# Altium schematic sheet dsPIC.SchDoc(dsPIC)
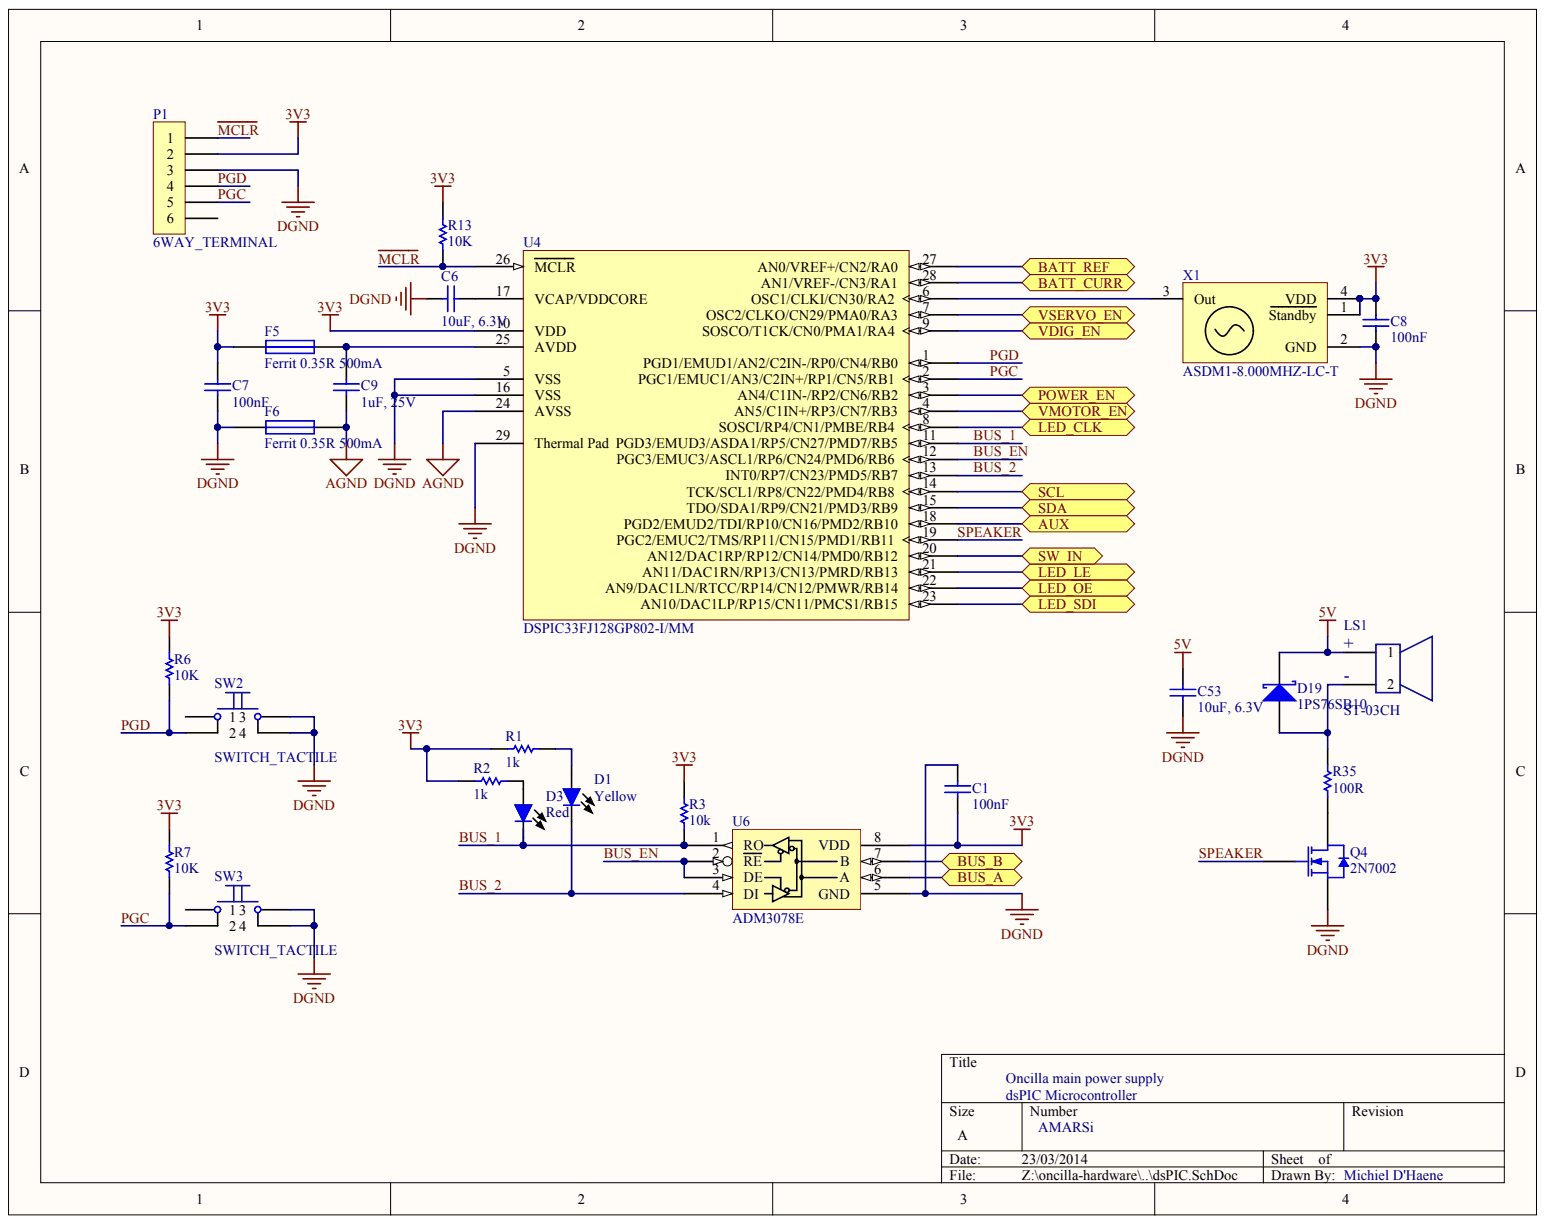
[diagram p0: dsPIC.SchDoc]

## Parts
C1  100nF
C6  10uF, 6.3V
C7  100nF
C8  100nF
C9  1uF, 25V
C53  value 10uF, 6.3V  (hidden comment: 1uF, 25V)
D1  Color: Yellow  (hidden comment: LED Yellow)
D3  Color: Red  (hidden comment: LED Red)
D19  1PS76SB10
F5  Ferrit 0.35R 500mA
F6  Ferrit 0.35R 500mA
LS1  ST-03CH
P1  6WAY_TERMINAL
Q4  2N7002
R1  1k
R2  1k
R3  10k
R6  10k
R7  10k
R13  10k
R35  100R
SW2  SWITCH_TACTILE
SW3  SWITCH_TACTILE
U4  DSPIC33FJ128GP802-I/MM
U6  ADM3078E
X1  ASDM1-8.000MHZ-LC-T

## Ports
AUX, BATT_CURR, BATT_REF, BUS_A, BUS_B, LED_CLK, LED_LE, LED_SDI, LED_~{OE}, POWER_EN, SCL, SDA, SW_IN, VDIG_EN, VMOTOR_EN, VSERVO_EN

## Nets
3V3: C1.1 C7.2 C8.2 F5.1 P1.2 R1.1 R2.1 R3.2 R6.2 R7.2 R13.2 U4.10(VDD) U6.8(VDD) X1.1(Standby) X1.4(VDD)
5V: C53.1 D19.1 LS1.1
AGND: C9.1 F6.2 U4.24(AVSS)
DGND: C1.2 C6.1 C7.1 C8.1 C53.2 F6.1 P1.3 Q4.2 SW2.3 SW2.4 SW3.3 SW3.4 U4.5(VSS) U4.16(VSS) U4.29(Thermal_Pad) U6.5(GND) X1.2(GND)
AUX: U4.18(PGD2/EMUD2/TDI/RP10/CN16/PMD2/RB10) ; port AUX
BATT_CURR: U4.28(AN1/VREF-/CN3/RA1) ; port BATT_CURR
BATT_REF: U4.27(AN0/VREF+/CN2/RA0) ; port BATT_REF
BUS_1: D3.2 R3.1 U4.11(PGD3/EMUD3/ASDA1/RP5/CN27/PMD7/RB5) U6.1(RO)
BUS_2: D1.2 U4.13(INT0/RP7/CN23/PMD5/RB7) U6.4(DI)
BUS_A: U6.6(A) ; port BUS_A
BUS_B: U6.7(B) ; port BUS_B
BUS_EN: U4.12(PGC3/EMUC3/ASCL1/RP6/CN24/PMD6/RB6) U6.2(RE) U6.3(DE)
LED_CLK: U4.8(SOSCI/RP4/CN1/PMBE/RB4) ; port LED_CLK
LED_LE: U4.21(AN11/DAC1RN/RP13/CN13/PMRD/RB13) ; port LED_LE
LED_~{OE}: U4.22(AN9/DAC1LN/RTCC/RP14/CN12/PMWR/RB14) ; port LED_~{OE}
LED_SDI: U4.23(AN10/DAC1LP/RP15/CN11/PMCS1/RB15) ; port LED_SDI
~{MCLR}: P1.1 R13.1 U4.26(MCLR)
PGC: P1.5 R7.1 SW3.2 U4.2(PGC1/EMUC1/AN3/C2IN+/RP1/CN5/RB1)
PGD: P1.4 R6.1 SW2.2 U4.1(PGD1/EMUD1/AN2/C2IN-/RP0/CN4/RB0)
POWER_EN: U4.3(AN4/C1IN-/RP2/CN6/RB2) ; port POWER_EN
SCL: U4.14(TCK/SCL1/RP8/CN22/PMD4/RB8) ; port SCL
SDA: U4.15(TDO/SDA1/RP9/CN21/PMD3/RB9) ; port SDA
SPEAKER: Q4.1 U4.19(PGC2/EMUC2/TMS/RP11/CN15/PMD1/RB11)
SW_IN: U4.20(AN12/DAC1RP/RP12/CN14/PMD0/RB12) ; port SW_IN
VDIG_EN: U4.9(SOSCO/T1CK/CN0/PMA1/RA4) ; port VDIG_EN
VMOTOR_EN: U4.4(AN5/C1IN+/RP3/CN7/RB3) ; port VMOTOR_EN
VSERVO_EN: U4.7(OSC2/CLKO/CN29/PMA0/RA3) ; port VSERVO_EN
NetC6_2: C6.2 U4.17(VCAP/VDDCORE)
NetC9_2: C9.2 F5.2 U4.25(AVDD)
NetD1_1: D1.1 R1.2
NetD3_1: D3.1 R2.2
NetD19_2: D19.2 LS1.2 R35.1
NetQ4_3: Q4.3 R35.2
NetU4_6: U4.6(OSC1/CLKI/CN30/RA2) X1.3(Out)

Not connected: P1 6; SW2 1; SW3 1

## Library data of the parts
C53 = (no symbol name): Value: 10uF, 6.3V; Published: 8-Jun-2000; LatestRevisionDate: 29-May-2009; LatestRevisionNote: IPC-7351 Footprint Added.; PackageReference: C1206; PackageDescription: Chip Capacitor; Publisher: Altium Limited
X1 = (no symbol name): Name: ASDM1; Value: 8MHz
C1 C6-C9 D1 D3 D19 F5 F6 LS1 P1 Q4 R1-R3 R6 R7 R13 R35 SW2 SW3 U4 U6 = (no symbol name)
  C1 C7 C8: Published: 8-Jun-2000; LatestRevisionDate: 29-May-2009; LatestRevisionNote: IPC-7351 Footprint Added.; PackageReference: C1206; PackageDescription: Chip Capacitor; Value: 100nF
  C6: Published: 8-Jun-2000; LatestRevisionDate: 29-May-2009; LatestRevisionNote: IPC-7351 Footprint Added.; PackageReference: C1206; PackageDescription: Chip Capacitor; Value: 10uF, 6.3V
  C9: Published: 8-Jun-2000; LatestRevisionDate: 29-May-2009; LatestRevisionNote: IPC-7351 Footprint Added.; PackageReference: C1206; PackageDescription: Chip Capacitor; Value: 1uF, 25V
  D19: Published: 17-Sep-2003; LatestRevisionDate: 19-Mar-2004; LatestRevisionNote: Silkscreen size reduced.; DatasheetVersion: 14-Oct-1996; PackageVersion: May-1999; PackageReference: SOD323; PackageDescription: SOD-323/SC-76; 2 Leads; Body 1.7 x 1.3 mm, (LxW); ComponentLink1Description: Manufacturer Link; ComponentLink1URL: http://www.semiconductors.philips.com/; ComponentLink2Description: Datasheet; ComponentLink2URL: http://www.semiconductors.philips.com/acrobat/datasheets/1PS76SB10_2.pdf
  F5 F6: Published: 8-Jun-2000; LatestRevisionDate: 17-Jul-2002; LatestRevisionNote: Re-released for DXP Platform.; PackageReference: PIN-W2/E2.8
  Q4: Published: 17-Sep-2003; LatestRevisionDate: 12-Dec-2006; LatestRevisionNote: IPC-7351 Footprint Added.; DatasheetVersion: 26-Jul-2000; PackageVersion: May-1999; PackageReference: SOT23; PackageDescription: 3-Pin SOT-23 Package 0.95 mm Pitch, 2.3 mm Lead Span; ComponentLink1Description: Manufacturer Link; ComponentLink1URL: http://www.semiconductors.philips.com/; ComponentLink2Description: Datasheet; ComponentLink2URL: http://www.semiconductors.philips.com/acrobat/datasheets/BST82-03.pdf
  R1 R2: Published: 8-Jun-2000; LatestRevisionDate: 17-Jul-2002; LatestRevisionNote: Re-released for DXP Platform.; PackageReference: AXIAL-0.5; Value: 1k
  R3: Published: 8-Jun-2000; LatestRevisionDate: 17-Jul-2002; LatestRevisionNote: Re-released for DXP Platform.; PackageReference: AXIAL-0.5; Value: 10k
  R6 R7 R13: Published: 8-Jun-2000; LatestRevisionDate: 17-Jul-2002; LatestRevisionNote: Re-released for DXP Platform.; PackageReference: AXIAL-0.5; Value: 10K
  R35: Published: 8-Jun-2000; LatestRevisionDate: 17-Jul-2002; LatestRevisionNote: Re-released for DXP Platform.; PackageReference: AXIAL-0.5; Value: 100R
  U4: FirstPublished: 29-Jan-2009; LatestRevisionDate: 02-Dec-2009; LatestRevisionNote: Updated to datasheet revision D, Nov-2009; DatasheetVersion: revD, Nov-2009; PackageVersion: revBB, Aug-2009; PackageReference: QFNS-MM28; PackageDescription: 28-Lead Plastic Quad Flat, No Lead Package (MM) - 6x6x0.9 mm Body [QFN-S] with 0.40 mm Contact Length; Supplier 1: Digi-Key; Supplier Part Number 1: DSPIC33FJ128GP802T-I/MMTR-ND; Supplier 2: Newark; Supplier Part Number 2: 54M4502; ComponentLink1Description: Datasheet; ComponentLink1URL: http://ww1.microchip.com/downloads/en/DeviceDoc/70292D.pdf; ComponentLink2Description: Packaging Specification; ComponentLink2URL: http://www.microchip.com/stellent/groups/techpub_sg/documents/packagingspec/en012702.pdf; ComponentLink3Description: Microchip.com Home; ComponentLink3URL: http://www.microchip.com/
  C1 C6-C9 D19 F5 F6 Q4 R1-R3 R6 R7 R13 R35 U4: Publisher: Altium Limited
